# Altium schematic sheet Ethernet.SchDoc(Ethernet)
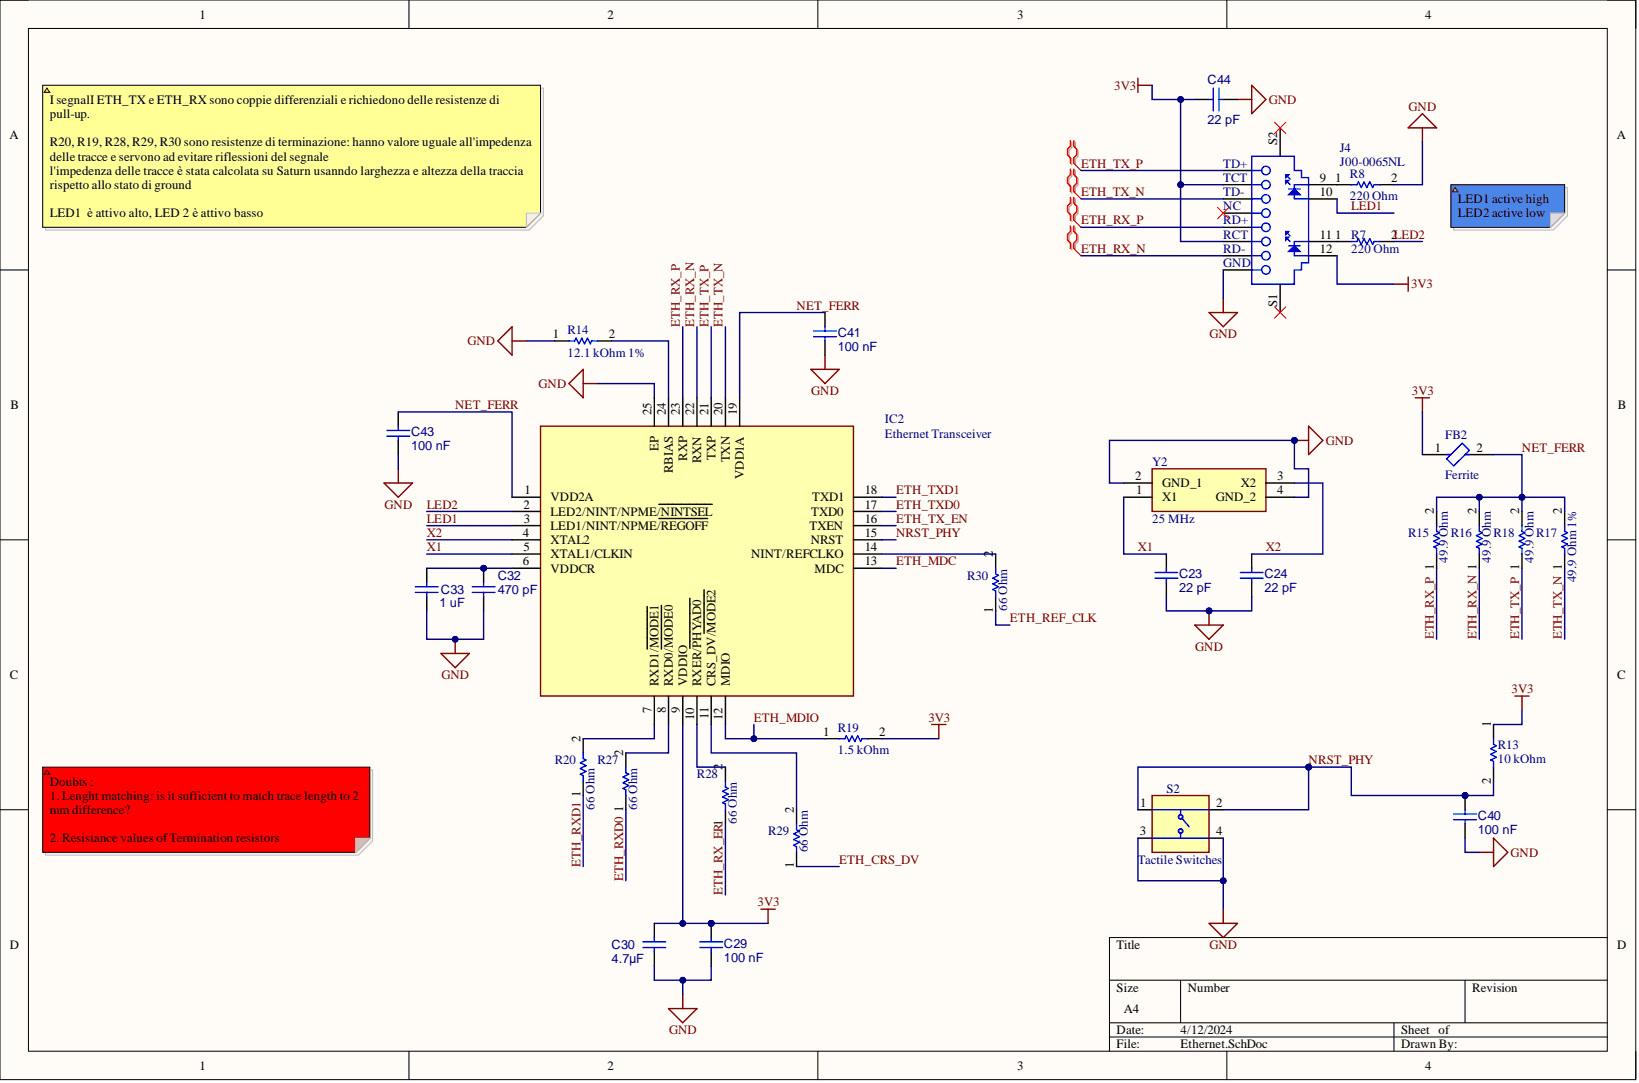
[diagram p0: Ethernet.SchDoc]

## Parts
C23  22 pF
C24  22 pF
C29  100 nF
C30  4.7µF
C32  470 pF
C33  1 uF
C40  100 nF
C41  100 nF
C43  100 nF
C44  22 pF
FB2  Ferrite
IC2  Ethernet Transceiver
J4  J00-0065NL
R7  220 Ohm
R8  220 Ohm
R13  10 kOhm
R14  12.1 kOhm 1%
R15  49.9 Ohm
R16  49.9 Ohm
R17  49.9 Ohm 1%
R18  49.9 Ohm
R19  1.5 kOhm
R20  66 Ohm
R27  66 Ohm
R28  66 Ohm
R29  66 Ohm
R30  66 Ohm
S2  Tactile Switches
Y2  25 MHz

## Nets
3V3: C29.2 C30.2 C44.2 FB2.1 IC2.9(VDDIO) J4.2 J4.6 J4.12 R13.1 R19.2
GND: C23.1 C24.1 C29.1 C30.1 C32.1 C33.1 C40.1 C41.1 C43.1 C44.1 IC2.25(EP) J4.8 R8.2 R14.1 S2.3 S2.4 Y2.2(GND_1) Y2.4(GND_2)
ETH_CRS_DV: R29.1
ETH_MDC: IC2.13(MDC)
ETH_MDIO: IC2.12(MDIO) R19.1
ETH_REF_CLK: R30.1
ETH_RX_ER: R28.1
ETH_RX_N: IC2.22(RXN) J4.7 R16.1
ETH_RX_P: IC2.23(RXP) J4.5 R15.1
ETH_RXD0: R27.1
ETH_RXD1: R20.1
ETH_TX_EN: IC2.16(TXEN)
ETH_TX_N: IC2.20(TXN) J4.3 R17.1
ETH_TX_P: IC2.21(TXP) J4.1 R18.1
ETH_TXD0: IC2.17(TXD0)
ETH_TXD1: IC2.18(TXD1)
LED1: IC2.3(LED1/NINT/NPME/REGOFF) J4.10
LED2: IC2.2(LED2/NINT/NPME/NINTSEL) R7.2
NET_FERR: C41.2 C43.2 FB2.2 IC2.1(VDD2A) IC2.19(VDD1A) R15.2 R16.2 R17.2 R18.2
NRST_PHY: C40.2 IC2.15(NRST) R13.2 S2.1 S2.2
X1: C23.2 IC2.5(XTAL1/CLKIN) Y2.1(X1)
X2: C24.2 IC2.4(XTAL2) Y2.3(X2)
NetC32_2: C32.2 C33.2 IC2.6(VDDCR)
NetIC2_7: IC2.7(RXD1/MODE1) R20.2
NetIC2_8: IC2.8(RXD0/MODE0) R27.2
NetIC2_10: IC2.10(RXER/PHYAD0) R28.2
NetIC2_11: IC2.11(CRS_DV/MODE2) R29.2
NetIC2_14: IC2.14(NINT/REFCLKO) R30.2
NetIC2_24: IC2.24(RBIAS) R14.2
NetJ4_9: J4.9 R8.1
NetJ4_11: J4.11 R7.1

Not connected: J4 4 S1 S2

## Library data of the parts
C23 C24 C44 = CMP-001-00085-5: VJ0805A220KXAMC; Tech: SMD; Component Kind: Standard; ComponentLink1Description: Website; Package: 0805; Library Name: EMEA- Squadra Corse PoliTO-  Politecnico di Torino
C29 C40 C41 C43 = CMP-001-00085-5: VJ0805Y104MXATW1BC; Tech: SMD; Component Kind: Standard; ComponentLink1Description: Website; Package: 0805; Library Name: EMEA- Squadra Corse PoliTO-  Politecnico di Torino
C30 = CMP-001-00085-5: VJ0805G475KXQTW1BC; Tech: SMD; Component Kind: Standard; ComponentLink1Description: Website; Package: 0805; Library Name: EMEA- Squadra Corse PoliTO-  Politecnico di Torino
C32 = CMP-001-00085-5: VJ0805A471KXBBC31; Tech: SMD; Component Kind: Standard; ComponentLink1Description: Website; Package: 0805; Library Name: EMEA- Squadra Corse PoliTO-  Politecnico di Torino
C33 = CMP-001-00085-5: VJ0805Y105KXXTW1BC; Tech: SMD; Component Kind: Standard; ComponentLink1Description: Website; Package: 0805; Library Name: EMEA- Squadra Corse PoliTO-  Politecnico di Torino
FB2 = CMP-06046-002291-1: BLM31KN102SH1L; DC Resistance (DCR): 75mR; Max Current Rating: 2A; Number of Lines: 1; Case Code (Imperial): 1206; Case Code (Metric): 3216; Case/Package: 1206; Component Kind: Standard; Library Name: Altium Content Vault; Max Operating Temperature: 125°C; Min Operating Temperature: -55°C; Mount: Surface Mount; Packaging: Cut Tape; Ratings: AEC-Q200; RoHS Compliant: Yes
IC2 = CMP-030-000054-1: LAN8742AI-CZ; Manufacturer_Name: Microchip; Arrow Part Number: LAN8742AI-CZ; Arrow Price/Stock: https://www.arrow.com/en/products/lan8742ai-cz/microchip-technology?region=nac; Component Kind: Standard; Datasheet Link: http://ww1.microchip.com/downloads/en/DeviceDoc/8742a.pdf; Design Item ID: LAN8742AI-CZ; Height: 1mm; Manufacturer_Part_Number: LAN8742AI-CZ; Mouser Part Number: 579-LAN8742AI-CZ; Mouser Price/Stock: https://www.mouser.co.uk/ProductDetail/Microchip-Technology/LAN8742AI-CZ?qs=6qKXPk0vzx120nQgB0hn9A%3D%3D; Source: SamacSys.SchLib; Library Name: EMEA- Squadra Corse PoliTO-  Politecnico di Torino
J4 = CMP-002-00004-4: 1x1 Tab-Down RJ45, Pitch 1.27 mm, 8 Position, 0 to 70 degC, 8-Pin THD, RoHS, Bulk; Manufacturer: Pulse Electronics; Capacitance: -45f; Connector Type: SDCard; Gender: Female; Shielding: Shielded; Case/Package: J00-0065NL; Colour: Yellow; Component Kind: Standard; Contact Finish: Gold; Contact Material: Bronze; Contact Plating: Gold; Datasheet URL: http://productfinder.pulseeng.com/products/datasheets/J403.pdf; Datasheet Version: Aug-12; Lead Pitch: 2.54mm; Manufacturer URL: http://www.pulseelectronics.com/; Max Operating Temperature: 70°C; Min Operating Temperature: 0°C; Mounting Technology: TH; Package Description: 12-Pin Through Hole Device, Body 21.59 x 16.26 mm, Pitch 1.27 mm; Package Quantity: 25; Package Reference: J00-0065NL; Packaging: Bulk; Pins: 8; RoHS Compliant: True; Library Name: EMEA- Squadra Corse PoliTO-  Politecnico di Torino
R7 R8 = CMP-00010-3: CRCW0603220RJNEA; Component Kind: Standard; Library Name: EMEA- Squadra Corse PoliTO-  Politecnico di Torino
R13 = CMP-00010-3: CRCW060310K0FKEA; Component Kind: Standard; Library Name: EMEA- Squadra Corse PoliTO-  Politecnico di Torino
R14 = CMP-00010-3: CRCW060312K1FKEA; Component Kind: Standard; Library Name: EMEA- Squadra Corse PoliTO-  Politecnico di Torino
R15-R18 = CMP-00010-3: CRCW060349R9FKEB; Component Kind: Standard; Library Name: EMEA- Squadra Corse PoliTO-  Politecnico di Torino
R19 = CMP-00010-3: CRCW06031K50FKEC; Component Kind: Standard; Library Name: EMEA- Squadra Corse PoliTO-  Politecnico di Torino
R20 R27-R29 = CMP-00010-3: CRCW060366R5FKEA; Component Kind: Standard; Library Name: EMEA- Squadra Corse PoliTO-  Politecnico di Torino
R30 = CMP-00010-3: General Resistor 0603; Component Kind: Standard; Library Name: EMEA- Squadra Corse PoliTO-  Politecnico di Torino
S2 = CMP-011-000004-5: RKC2SJF170SMTR_LFS; Manufacturer_Name: C & K COMPONENTS; Manufacturer_Part_Number: RKC2SJF170SMTR LFS; Arrow Part Number: RKC2SJF170SMTR LFS; Arrow Price/Stock: https://www.arrow.com/en/products/rkc2sjf170smtr-lfs/ck?region=nac; Component Kind: Standard; Datasheet Link: https://www.ckswitches.com/media/3470/ck_rkc2_datasheet.pdf; Design Item ID: RKC2SJF170SMTR_LFS; Height: 1.9mm; Mouser Part Number: 611-RKC2SJF170SMRLFS; Mouser Price/Stock: https://www.mouser.co.uk/ProductDetail/CK/RKC2SJF170SMTR-LFS?qs=XAiT9M5g4x%252BRRI9derenng%3D%3D; Source: SamacSys.SchLib; Library Name: EMEA- Squadra Corse PoliTO-  Politecnico di Torino
Y2 = CMP-123-000001-1: ABMM1-25.000MHZ-16-T; Manufacturer_Name: ABRACON; Component Kind: Standard; Datasheet Link: https://abracon.com/Resonators/ABMM.pdf; Design Item ID: ABMM1-25.000MHZ-16-T; Height: 1.2mm; Manufacturer_Part_Number: ABMM1-25.000MHZ-16-T; Source: SamacSys.SchLib; Library Name: EMEA- Squadra Corse PoliTO-  Politecnico di Torino
